# Altium schematic sheet wandtext.SchDoc
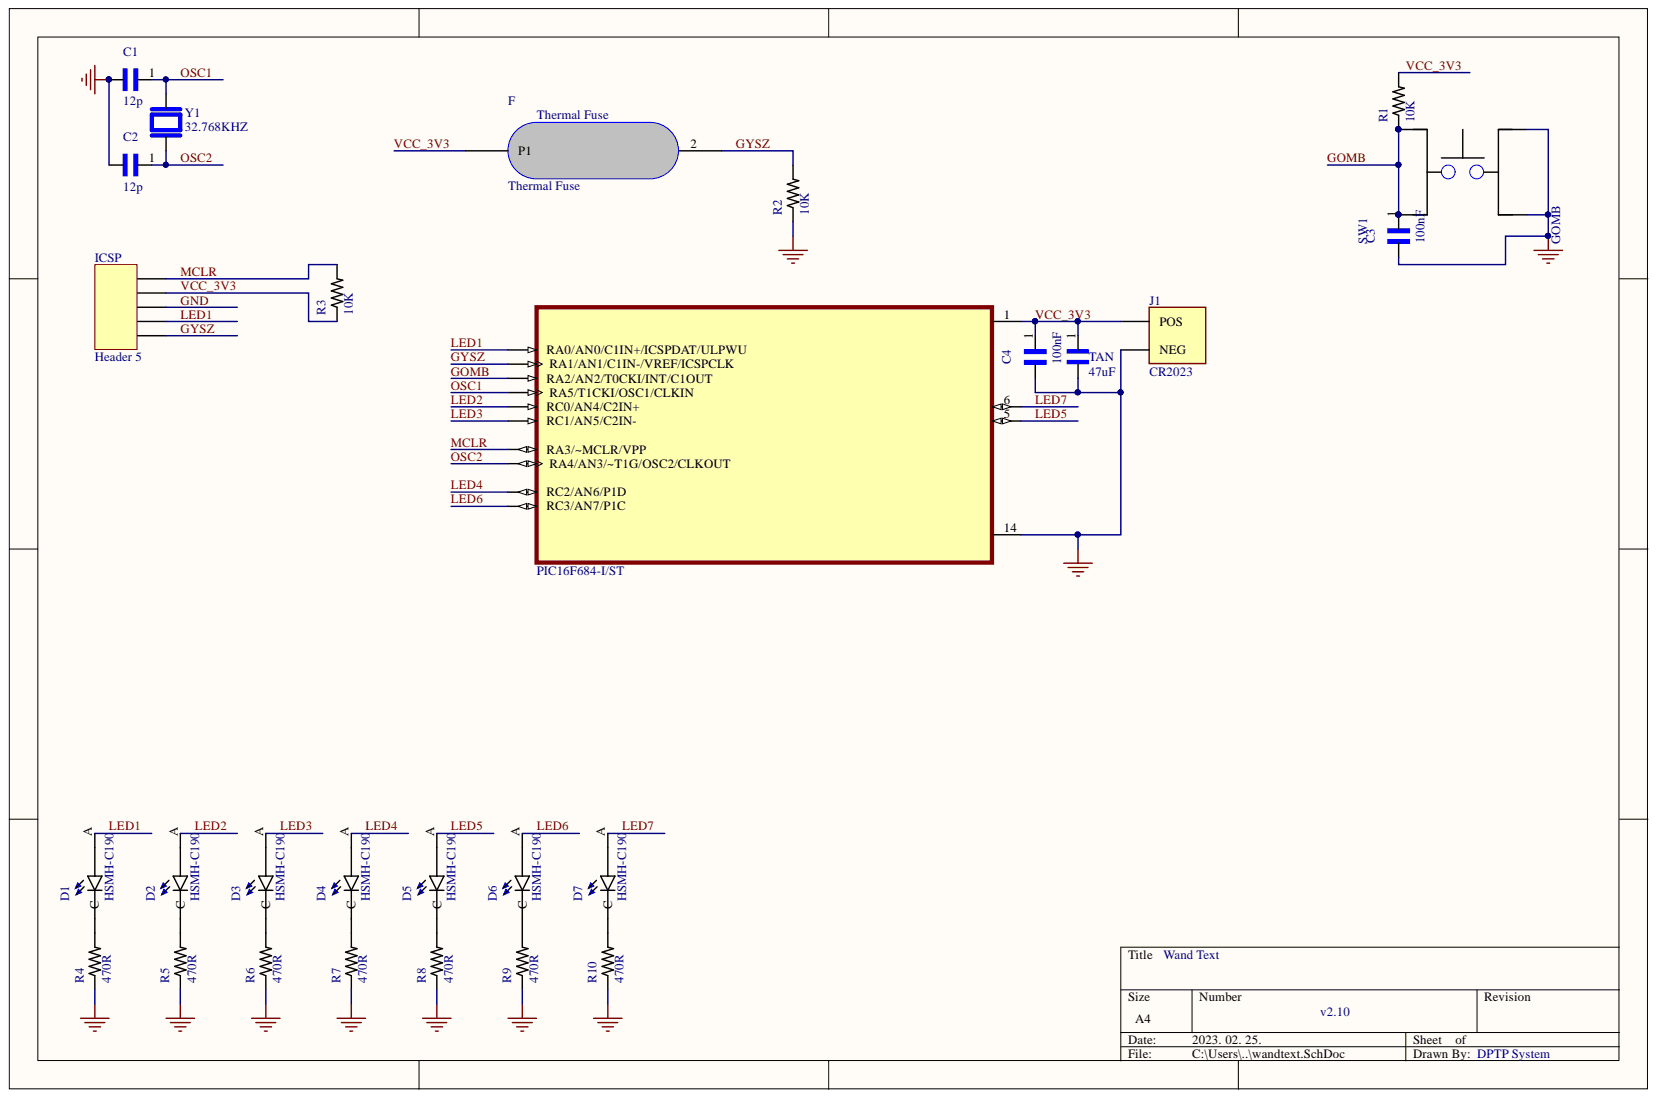
[diagram p0: wandtext.SchDoc]

## Parts
C1  12p  [CAP0603]
C2  12p  [CAP0603]
C3  100nF  [CAP0603]
C4  100nF  [CAP0603]
D1  HSMH-C190  [LEDM1608X80_HSMH-C190(Primary)]
D2  HSMH-C190  [LEDM1608X80_HSMH-C190(Primary)]
D3  HSMH-C190  [LEDM1608X80_HSMH-C190(Primary)]
D4  HSMH-C190  [LEDM1608X80_HSMH-C190(Primary)]
D5  HSMH-C190  [LEDM1608X80_HSMH-C190(Primary)]
D6  HSMH-C190  [LEDM1608X80_HSMH-C190(Primary)]
D7  HSMH-C190  [LEDM1608X80_HSMH-C190(Primary)]
F  Thermal Fuse  [PCBComponent_1]
ICSP  Header 5  [HDR1X5]
J1  CR2023  [TE_796136-1]
R1  10K  [RES0603]
R2  10K  [RES0603]
R3  10K  [RES0603]
R4  470R  [RES0603]
R5  470R  [RES0603]
R6  470R  [RES0603]
R7  470R  [RES0603]
R8  470R  [RES0603]
R9  470R  [RES0603]
R10  470R  [RES0603]
SW1  GOMB  [SW_TL3342F160QG/TR]
TAN  47uF  [CAPMP3216X180N]
Y1  32.768KHZ  [XTAL_MS1V-T1K-32.768KHZ-7PF-20PPM-TA-QC-AU]
  PIC16F684-I/ST  [SOP65P640X120-14N]

## Nets
GND: C1.2 C2.2 C3.2 C4.2 ICSP.3 J1.2 R2.1 R4.1 R5.1 R6.1 R7.1 R8.1 R9.1 R10.1 SW1.1 SW1.2 TAN.2 .14
GOMB: C3.1 R1.1 SW1.3 SW1.4 .11(RA2/AN2/T0CKI/INT/C1OUT)
GYSZ: F.2 ICSP.1 R2.2 .12(RA1/AN1/C1IN-/VREF/ICSPCLK)
LED1: D1.A ICSP.2 .13(RA0/AN0/C1IN+/ICSPDAT/ULPWU)
LED2: D2.A .10(RC0/AN4/C2IN+)
LED3: D3.A .9(RC1/AN5/C2IN-)
LED4: D4.A .8(RC2/AN6/P1D)
LED5: D5.A .5
LED6: D6.A .7(RC3/AN7/P1C)
LED7: D7.A .6
MCLR: ICSP.5 R3.2 .4(RA3/~MCLR/VPP)
OSC1: C1.1 Y1.2 .2(RA5/T1CKI/OSC1/CLKIN)
OSC2: C2.1 Y1.1 .3(RA4/AN3/~T1G/OSC2/CLKOUT)
VCC_3V3: C4.1 F.1 ICSP.4 J1.1 R1.2 R3.1 TAN.1 .1
NetD1_C: D1.C R4.2
NetD2_C: D2.C R5.2
NetD3_C: D3.C R6.2
NetD4_C: D4.C R7.2
NetD5_C: D5.C R8.2
NetD6_C: D6.C R9.2
NetD7_C: D7.C R10.2

## Library data of the parts
C1-C4 = 0603: Cap Ceramic; MF: AVX; MP: 06031A6R0BAT4A; Availability: Unavailable; Component Kind: Standard; Package: 0603 AVX; Price: None; SnapEDA_Link: https://www.snapeda.com/parts/06031A6R0BAT4A/AVX/view-part/?ref=snap; Library Name: Peti.SchLib
D1-D7 = HSMH-C190: LED; SMD; 0603; red; 7.2-17mcd; 1.6x0.8mm; 170Â°; 1.8Ă·2.6V; 20mA; MF: Broadcom Limited; Availability: Unavailable; Component Kind: Standard; MP: HSMH-C190; Package: 0603 Broadcom; Price: None; SnapEDA_Link: https://www.snapeda.com/parts/HSMH-C190/Broadcom%20Limited/view-part/?ref=snap; Library Name: Peti.SchLib
ICSP = Header 5: Header, 5-Pin; Component Kind: Standard; LatestRevisionDate: 17-Jul-2002; LatestRevisionNote: Re-released for DXP Platform.; Library Name: Miscellaneous Connectors.IntLib; Signal Integrity: Connector; Publisher: Altium Limited
J1 = 796136-1: BATTERY HOLDER COIN 20MM SMD; Availability: Unavailable; Component Kind: Standard; Library Name: 796136-1.IntLib; MP: 796136-1; Package: None; Price: None
R1-R10 = 0603RES: MF: Panasonic; MP: EXB-34V103JV; Availability: Unavailable; Component Kind: Standard; Description: 0603RES; Package: 0606 Panasonic; Price: None; SnapEDA_Link: https://www.snapeda.com/parts/EXB-34V103JV/Panasonic%20Electronic%20Components/view-part/?ref=snap; Library Name: Peti.SchLib
SW1 = TL3342F160QG/TR: Switch Tactile Spst-No 0.05a 12v; MF: E-Switch; Availability: Unavailable; Component Kind: Standard; MP: TL3342F160QG/TR; Package: FakePackage u; Price: None; SnapEDA_Link: https://www.snapeda.com/parts/TL3342F160QG/TR/E-Switch/view-part/?ref=snap; Library Name: Peti.SchLib
TAN = TAJD476K010TNJV: 47ÂµF Molded Tantalum Capacitors 10V 2917 _7343 Metric_ 400mOhm @ 100kHz; MF: AVX; Availability: Unavailable; Component Kind: Standard; Library Name: TAJD.SchLib; MP: TAJD476K010TNJV; Package: 2917 AVX; Price: None; SnapEDA_Link: https://www.snapeda.com/parts/TAJD476K010TNJV/AVX/view-part/2773833/?ref=snap
Y1 = MS1V-T1K-32.768KHZ-7PF-20PPM-TA-QC-AU: LOW FREQUENCY CRYSTAL; MF: Micro Crystal; Check_prices: https://www.snapeda.com/parts/MS1V-T1K-32.768kHz-7pF-20PPM-TA-QC-Au/Micro%20Crystal/view-part/3217479/?ref=eda; Component Kind: Standard; Digi-Key_Part_Number: 2195-MS1V-T1K-32.768KHZ-7PF-20PPM-TA-QC-AUCT-ND; Library Name: MS1V-T1K-32.768KHZ-7PF-20PPM-TA-QC-AU.IntLib; MP: MS1V-T1K-32.768kHz-7pF-20PPM-TA-QC-Au; Package: SMD-3 Micro Crystal; Purchase-URL: https://pricing.snapeda.com/search/part/MS1V-T1K-32.768kHz-7pF-20PPM-TA-QC-Au/?ref=eda; SnapEDA_Link: https://www.snapeda.com/parts/MS1V-T1K-32.768kHz-7pF-20PPM-TA-QC-Au/Micro%20Crystal/view-part/3217479/?ref=snap
 = PIC16F684-I/ST: PIC PICÂ® 16F Microcontroller IC 8-Bit 20MHz 3.5KB _2K x 14_ FLASH 14-TSSOP; MF: Microchip; Availability: Unavailable; Component Kind: Standard; Library Name: SnapEDAsch.SchLib; MP: PIC16F684-I/ST; Package: TSSOP-14 Microchip; Price: None; SnapEDA_Link: https://www.snapeda.com/parts/PIC16F684-I/ST/Microchip/view-part/5726/?ref=snap
F = Thermal Fuse: Component Kind: Standard; Description: Thermal Fuse; Library Name: thermal_fuse.SchLib
